# Altium schematic sheet sdflowmeter.SchDoc(sdflowmeter)
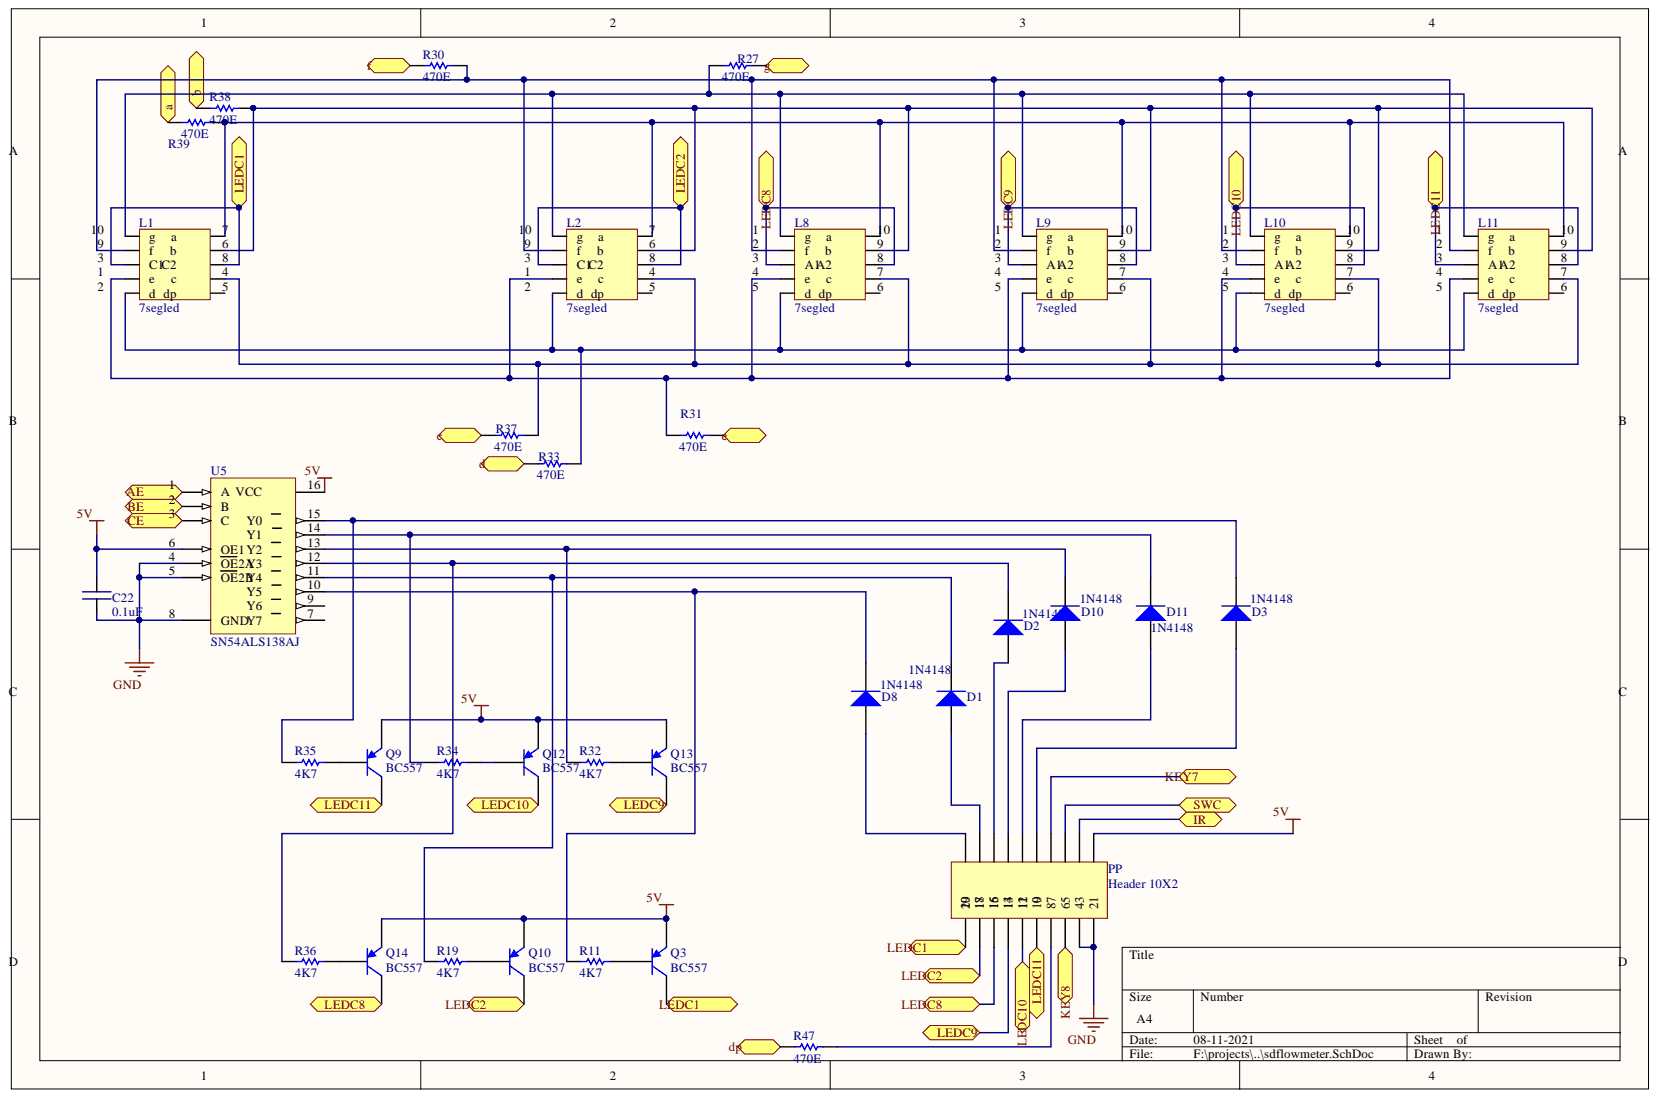
[diagram p0: sdflowmeter.SchDoc]

## Parts
C22  0.1uF  [0805]
D1  1N4148  [CMELF]
D2  1N4148  [CMELF]
D3  1N4148  [CMELF]
D8  1N4148  [CMELF]
D10  1N4148  [CMELF]
D11  1N4148  [CMELF]
L1  7segled  [DIP10CCLED]
L2  7segled  [DIP10CCLED]
L8  7segled  [DIP10CCLED10mm]
L9  7segled  [DIP10CCLED10mm]
L10  7segled  [DIP10CCLED10mm]
L11  7segled  [DIP10CCLED10mm]
PP  Header 10X2  [HDR2X10]
Q3  BC557  [SOT-23]
Q9  BC557  [SOT-23]
Q10  BC557  [SOT-23]
Q12  BC557  [SOT-23]
Q13  BC557  [SOT-23]
Q14  BC557  [SOT-23]
R11  4K7  [0805]
R19  4K7  [0805]
R27  470E  [0805]
R30  470E  [0805]
R31  470E  [0805]
R32  4K7  [0805]
R33  470E  [0805]
R34  4K7  [0805]
R35  4K7  [0805]
R36  4K7  [0805]
R37  470E  [0805]
R38  470E  [0805]
R39  470E  [0805]
R47  470E  [0805]
U5  SN54ALS138AJ  [SOIC16]

## Ports
A, AE, B, BE, C, CE, D, DP, E, F, G, IR, KEY7, KEY8, LEDC1, LEDC2, LEDC8, LEDC9, LEDC10, LEDC11, SWC

## Nets
5V: C22.1 PP.1 Q3.2 Q9.2 Q10.2 Q12.2 Q13.2 Q14.2 U5.6 U5.16
GND: C22.2 PP.2(2_1) PP.4(4_3) U5.4(OE2A) U5.5(OE2B) U5.8(GND)
AE: U5.1(A) ; port AE
BE: U5.2(B) ; port BE
CE: U5.3(C) ; port CE
IR: PP.3 ; port IR
KEY7: PP.7 ; port KEY7
KEY8: PP.6(6_5) ; port KEY8
LEDC1: L1.3(C1) L1.8 PP.20 Q3.3 ; port LEDC1
LEDC2: L2.3(C1) L2.8 PP.18 Q10.3 ; port LEDC2
LEDC8: L8.3(A1) L8.8 PP.16 Q14.3 ; port LEDC8
LEDC9: L9.3(A1) L9.8 PP.14 Q13.3 ; port LEDC9
LEDC10: L10.3(A1) L10.8 PP.12 Q12.3 ; port LEDC10
LEDC11: L11.3(A1) L11.8 PP.10 Q9.3 ; port LEDC11
SWC: PP.5 ; port SWC
NetD1_1: D1.1 PP.17
NetD1_2: D1.2 R19.1 U5.11
NetD2_1: D2.1 PP.15
NetD2_2: D2.2 R36.1 U5.12
NetD3_1: D3.1 PP.9
NetD3_2: D3.2 R35.1 U5.15
NetD8_1: D8.1 PP.19
NetD8_2: D8.2 R11.1 U5.10
NetD10_1: D10.1 PP.13
NetD10_2: D10.2 R32.1 U5.13
NetD11_1: D11.1 PP.11
NetD11_2: D11.2 R34.1 U5.14
NetL1_1: L1.1(e) L2.1(e) L8.4(e) L9.4(e) L10.4(e) L11.4(e) R31.1
NetL1_2: L1.2(d) L2.2(d) L8.5(d) L9.5(d) L10.5(d) L11.5(d) R33.2
NetL1_4: L1.4 L2.4 L8.7 L9.7 L10.7 L11.7 R37.2
NetL1_6: L1.6 L2.6 L8.9 L9.9 L10.9 L11.9 R38.2
NetL1_7: L1.7 L2.7 L8.10 L9.10 L10.10 L11.10 R39.2
NetL1_9: L1.9(f) L2.9(f) L8.2(f) L9.2(f) L10.2(f) L11.2(f) R30.2
NetL1_10: L1.10(g) L2.10(g) L8.1(g) L9.1(g) L10.1(g) L11.1(g) R27.1
NetPP_8: PP.8(8_7) R47.2
NetQ3_1: Q3.1 R11.2
NetQ9_1: Q9.1 R35.2
NetQ10_1: Q10.1 R19.2
NetQ12_1: Q12.1 R34.2
NetQ13_1: Q13.1 R32.2
NetQ14_1: Q14.1 R36.2
NetR27_2: R27.2 ; port G
NetR30_1: R30.1 ; port F
NetR31_2: R31.2 ; port E
NetR33_1: R33.1 ; port D
NetR37_1: R37.1 ; port C
NetR38_1: R38.1 ; port B
NetR39_1: R39.1 ; port A
NetR47_1: R47.1 ; port DP

Not connected: L1 5; L2 5; L8 6; L9 6; L10 6; L11 6; U5 7 9

## Library data of the parts
C22 = cap0805: Component Kind: Standard; Library Name: myaltiumsch.SchLib
D1-D3 D8 D10 D11 = Diode: Default Diode; Code_JEDEC: DO-214-AB; Component Kind: Standard; LatestRevisionDate: 17-Jul-2002; LatestRevisionNote: Re-released for DXP Platform.; Library Name: Miscellaneous Devices.IntLib; PackageDescription: DO-214-AB/SMC; 2 C-Bend Leads; Body 7.9 x 5.9 mm, inc. leads (LxW); PackageReference: SMC; PackageVersion: Sep-1996; Published: 8-Jun-2000; Signal Integrity: Diode; Simulation: DIODE; Publisher: Altium Limited
L1 L2 = 7SEGLEDCC: Component Kind: Standard; Library Name: myaltiumsch.SchLib
PP = Header 10X2: Header, 10-Pin, Dual row; Component Kind: Standard; LatestRevisionDate: 17-Jul-2002; LatestRevisionNote: Re-released for DXP Platform.; Library Name: Miscellaneous Connectors.IntLib; Signal Integrity: Connector; Publisher: Altium Limited
Q3 Q9 Q10 Q12-Q14 = PNP: PNP Bipolar Transistor; Component Kind: Standard; LatestRevisionDate: 29-May-2009; LatestRevisionNote: IPC-7351 Footprint Added.; PackageDescription: 3-Pin SOT-23 Package 0.95 mm Pitch, 2.4 mm Lead Span; PackageReference: SOT-23B; PackageVersion: Aug-1999; Published: 8-Jun-2000; Signal Integrity: PNP; Simulation: PNP; Library Name: myaltiumsch.SchLib; Publisher: Altium Limited
R11 R19 R27 R30-R39 R47 = RES: Resistor; Component Kind: Standard; LatestRevisionDate: 17-Jul-2002; LatestRevisionNote: Re-released for DXP Platform.; PackageReference: AXIAL-0.5; Published: 8-Jun-2000; Signal Integrity: Res Semi; Simulation: RES; Library Name: myaltiumsch.SchLib; Publisher: Altium Limited
U5 = SN54ALS138AJ: 3-Line to 8-Line Decoder / Demultiplexer; Component Kind: Standard; ComponentLink1Description: Manufacturer Link; ComponentLink1URL: http://www.ti.com/; ComponentLink2Description: Datasheet; ComponentLink2URL: http://www-s.ti.com/sc/ds/sn54als138a.pdf; DatasheetVersion: Jul-1996; LatestRevisionDate: 04-Mar-2005; LatestRevisionNote: Stylized 3D Model Added.; PackageDescription: DIP; 16 Leads; Row Spacing 7.62 mm; Pitch 2.54 mm; PackageReference: J016C; PackageVersion: Jun-1999; PCB3D: J016; Published: 8-Jun-2000; Signal Integrity: SN54ALS138AJ; Library Name: TI Logic Decoder Demux.IntLib; Publisher: Altium Limited
L8-L11 = 7segled: Component Kind: Standard; Library Name: myaltiumsch.SchLib
